# Altium schematic sheet OpenCellLoad.SchDoc
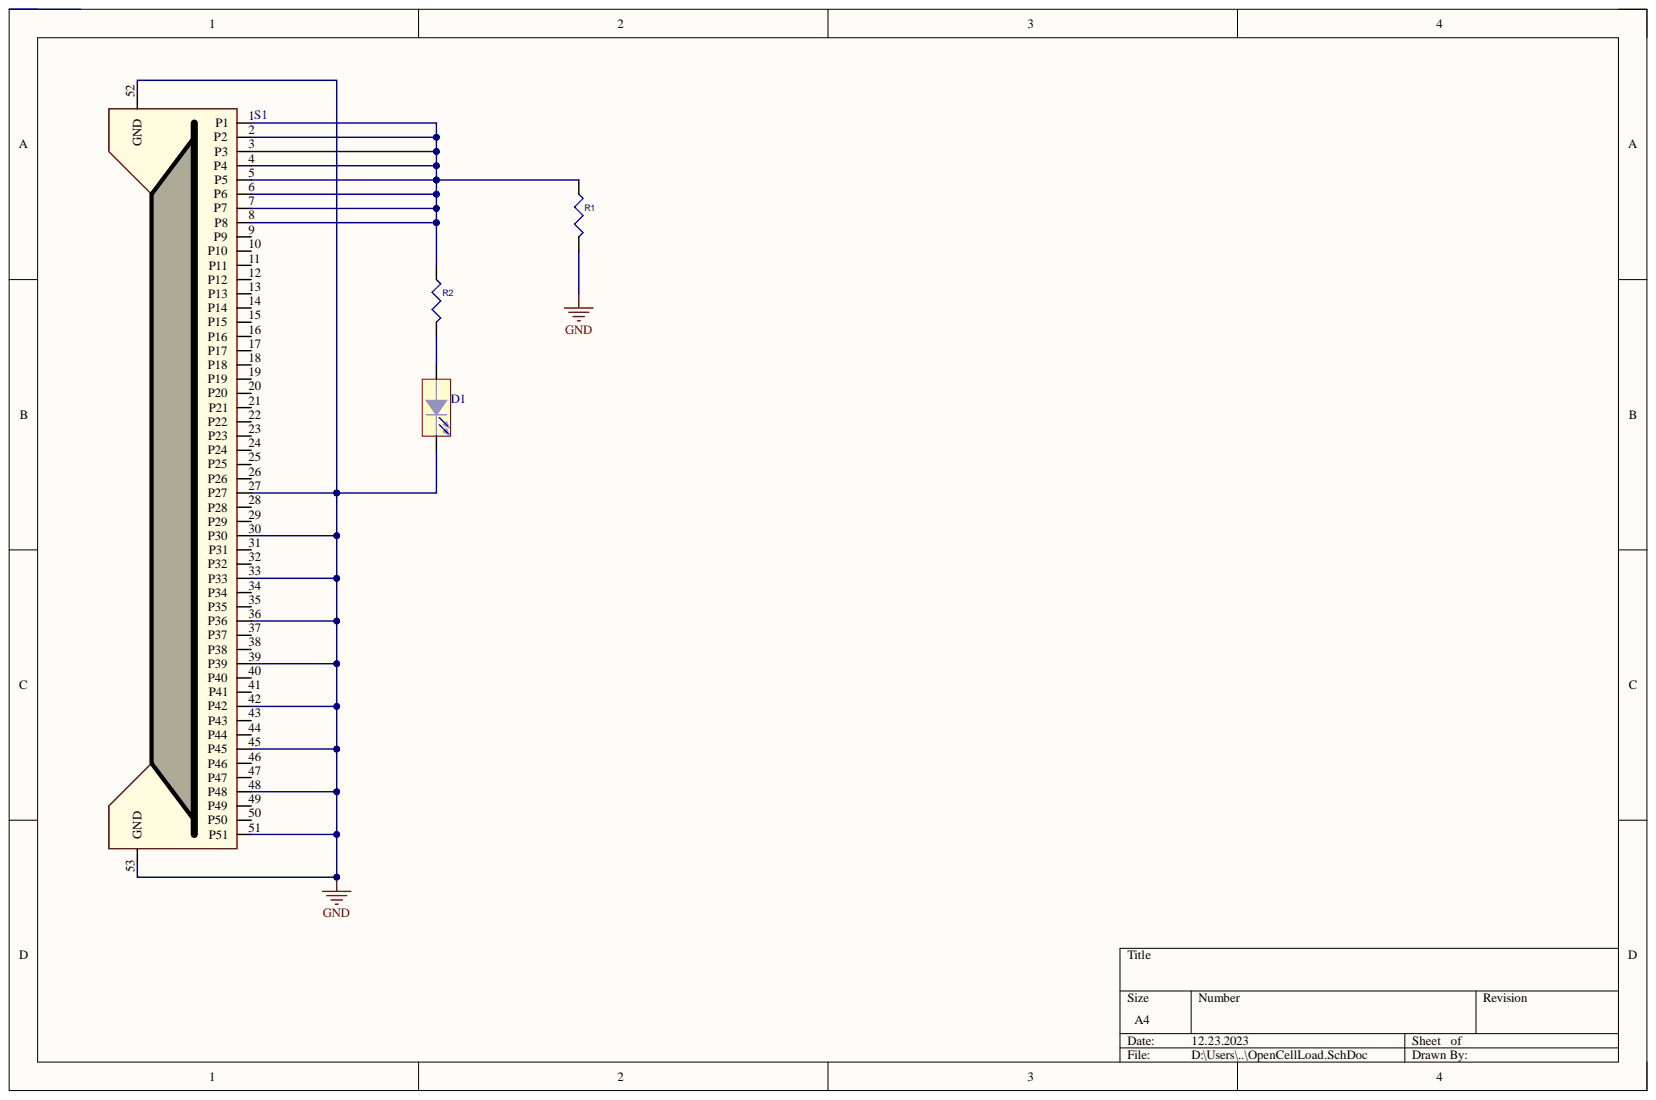
[diagram p0: OpenCellLoad.SchDoc]

## Parts
D1  SMD_LED  [4537]  (value not drawn)
R1  RESISTOR  [25WTAS_W]  (value not drawn)
R2  RESISTOR  [R0402_R]  (value not drawn)
S1  CON51+2  [3607]  (value not drawn)

## Nets
GND: D1.C R1.2 S1.27(P27) S1.30(P30) S1.33(P33) S1.36(P36) S1.39(P39) S1.42(P42) S1.45(P45) S1.48(P48) S1.51(P51) S1.52(GND) S1.53(GND)
NetD1_A: D1.A R2.2
NetR1_1: R1.1 R2.1 S1.1(P1) S1.2(P2) S1.3(P3) S1.4(P4) S1.5(P5) S1.6(P6) S1.7(P7) S1.8(P8)

Not connected: S1 9(P9) 10(P10) 11(P11) 12(P12) 13(P13) 14(P14) 15(P15) 16(P16) 17(P17) 18(P18) 19(P19) 20(P20) 21(P21) 22(P22) 23(P23) 24(P24) 25(P25) 26(P26) 28(P28) 29(P29) 31(P31) 32(P32) 34(P34) 35(P35) 37(P37) 38(P38) 40(P40) 41(P41) 43(P43) 44(P44) 46(P46) 47(P47) 49(P49) 50(P50)

## Library data of the parts
D1 = 300064R: LED-SMD TOP 0603 WHITE 3V T&R; Baloon_Code: X; Database Table Name: R_LED; Luminosity: 140mCd; Release_Status: AR3Standard_Part_Approved; Vendor_Number: L-C191WDT-EQ; Baloon_Name: X; Component Kind: Standard; ComponentLink2URL: \\plmsub01\common_nt\Altium\300064r_pdf_dot0zhk7yyesn.pdf; Library Name: Altium_20140916.DbLib; Part Number: 300064R; PN_INT: 300064R
R1 = AR-000001702103: 2: Wire Wound; Database Table Name: W_RESISTOR; Release_Status: AR3Standard_Part_Approved; Component Kind: Standard; ComponentLink2URL: \\plmsub01\common_nt\Altium\ar_0000_pdf_onn0y6oa4dew7.pdf; Library Name: Altium_20140916.DbLib; Part Number: AR-000001702103; PN_INT: AR-000001702103
R2 = 171515R: RC-CHIP 510R J 1/16W /0402 T&R; 2: Thick/Thin Film; Baloon_Code: X; Database Table Name: R_RESISTOR; M: SMD; Prefix: RC-SMD; Release_Status: AR3Standard_Part_Approved; 1: RESISTOR; Baloon_Name: X; Component Kind: Standard; ComponentLink1Description: OAF HISTORY; ComponentLink1URL: http://webserver/webprojects/isoweb/rapor/dooaf.asp?BasGun=01&BasAy=01&BasYil=2003&BitGun=31&BitAy=12&BitYil=9999&T5=&T2=171515&T3=171515s&T4=*&gonder=Rapor+Ver; ComponentLink2URL: \\ar.arcelik\arcdfs\byl\byl-ortak\ARGE\oafspec\474446.pdf; Library Name: Altium_20140916.DbLib; Package_Size: 0402; Part Number: 171515R; PCB_Footprint: R0402_R; PN_INT: 171515R; Power: 1/16W; Resistance: 510R; Tolerance: J
S1 = 039142R: CON-SMD Vx1 05030 SERIES 51PIN T&R; 1: CONNECTOR; 2: FFC/FPC; Baloon_Code: X; Database Table Name: R_CONNECTOR; Lead_Pitch: 0.5mm; M: SMD; Pin_Count: 51+2; Release_Status: AR3Standard_Part_Approved; Vendor_Number: 05030HR-H51C(G); Baloon_Name: X; Component Kind: Standard; ComponentLink1Description: http://webserver/webprojects/isoweb/rapor/dooaf.asp?BasGun=01&BasAy=01&BasYil=2003&BitGun=17&BitAy=04&BitYil=2017&T5=&T2=039142R&T3=039142R&T4=*&gonder=Rapor+Ver; ComponentLink1URL: \\arbyl20v\arge\oafspec\YEONHO\05030HR-H51C APPROVAL SHEET.pdf; ComponentLink2URL: \\plmsub01\common_nt\Altium\039142r_pdf_09b4if49leapp.pdf; Library Name: Altium_20140916.DbLib; Packaging_Style: T&R; Part Number: 039142R; PCB_Footprint: 3607; PN_INT: 039142R; Prefix: CON-SMD; Schematic: CON51+2
